# Altium schematic sheet LIDO-HW.200.MCU_Peripherals_1.SchDoc(LIDO-HW.200.MCU_Peripherals_1)
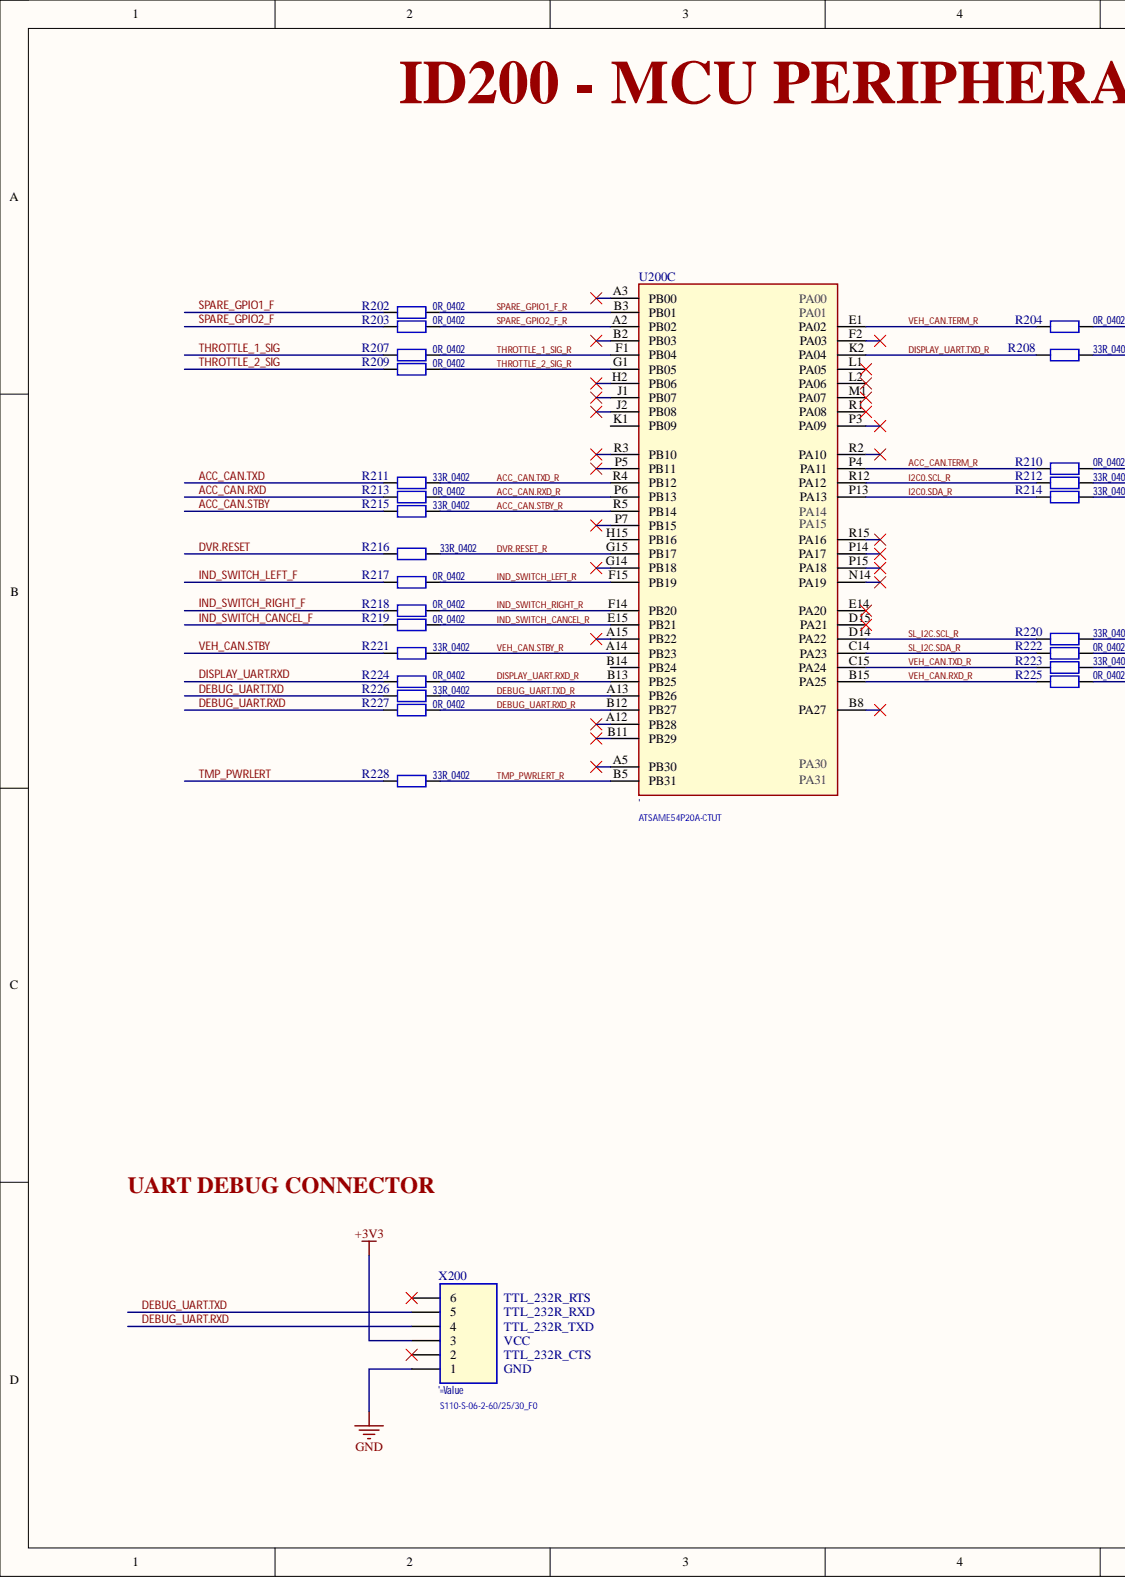
[diagram p0: LIDO-HW.200.MCU_Peripherals_1.SchDoc, left]
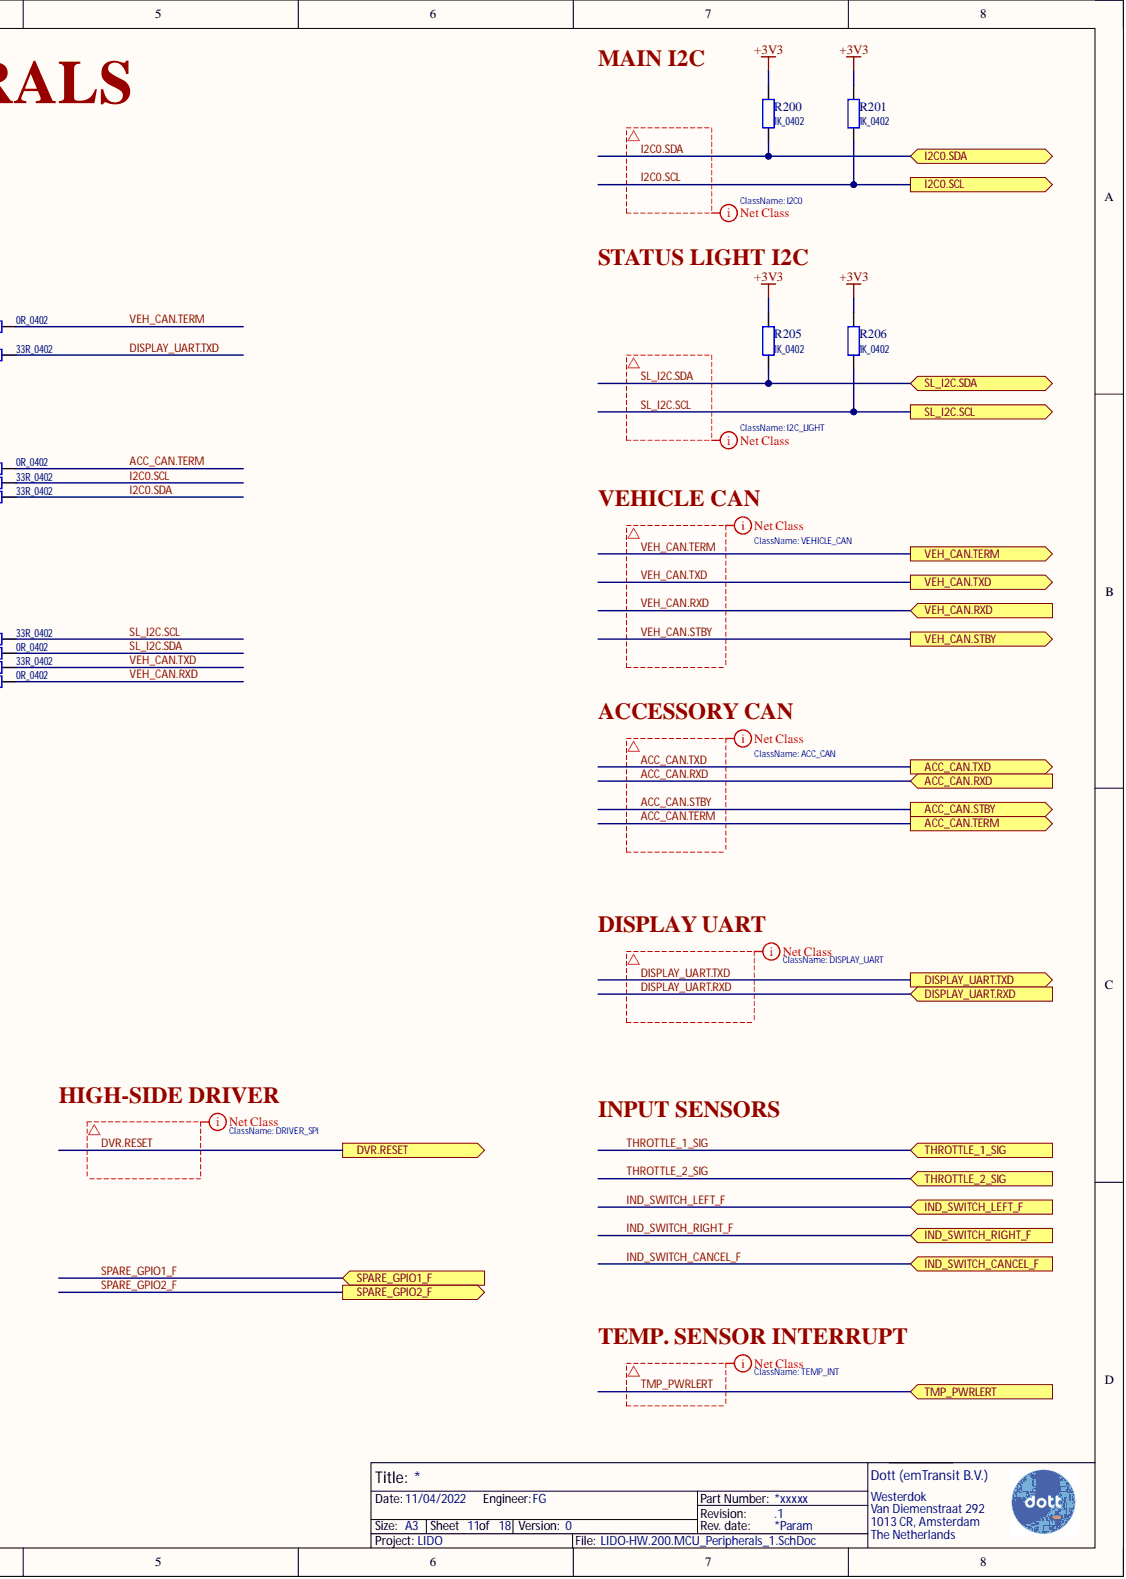
[diagram p1: LIDO-HW.200.MCU_Peripherals_1.SchDoc, right]

## Parts
R200  1K_0402
R201  1K_0402
R202  0R_0402
R203  0R_0402
R204  0R_0402
R205  1K_0402
R206  1K_0402
R207  0R_0402
R208  33R_0402
R209  0R_0402
R210  0R_0402
R211  33R_0402
R212  33R_0402
R213  0R_0402
R214  33R_0402
R215  33R_0402
R216  33R_0402
R217  0R_0402
R218  0R_0402
R219  0R_0402
R220  33R_0402
R221  33R_0402
R222  0R_0402
R223  33R_0402
R224  0R_0402
R225  0R_0402
R226  33R_0402
R227  0R_0402
R228  33R_0402
U200  '  (part C)
X200  '=Value

## Ports
ACC_CAN.RXD, ACC_CAN.STBY, ACC_CAN.TERM, ACC_CAN.TXD, DISPLAY_UART.RXD, DISPLAY_UART.TXD, DVR.RESET, I2C0.SCL, I2C0.SDA, IND_SWITCH_CANCEL_F, IND_SWITCH_LEFT_F, IND_SWITCH_RIGHT_F, SL_I2C.SCL, SL_I2C.SDA, SPARE_GPIO1_F, SPARE_GPIO2_F, THROTTLE_1_SIG, THROTTLE_2_SIG, TMP_PWRLERT, VEH_CAN.RXD, VEH_CAN.STBY, VEH_CAN.TERM, VEH_CAN.TXD

## Nets
+3V3: R200.1 R201.1 R205.1 R206.1 X200.3
GND: X200.1
ACC_CAN.RXD: R213.2 ; port ACC_CAN.RXD
ACC_CAN.RXD_R: R213.1 U200.P6(PB13)
ACC_CAN.STBY: R215.2 ; port ACC_CAN.STBY
ACC_CAN.STBY_R: R215.1 U200.R5(PB14)
ACC_CAN.TERM: R210.1 ; port ACC_CAN.TERM
ACC_CAN.TERM_R: R210.2 U200.P4(PA11)
ACC_CAN.TXD: R211.2 ; port ACC_CAN.TXD
ACC_CAN.TXD_R: R211.1 U200.R4(PB12)
DEBUG_UART.RXD: R227.2 X200.4
DEBUG_UART.RXD_R: R227.1 U200.B12(PB27)
DEBUG_UART.TXD: R226.2 X200.5
DEBUG_UART.TXD_R: R226.1 U200.A13(PB26)
DISPLAY_UART.RXD: R224.2 ; port DISPLAY_UART.RXD
DISPLAY_UART.RXD_R: R224.1 U200.B13(PB25)
DISPLAY_UART.TXD: R208.2 ; port DISPLAY_UART.TXD
DISPLAY_UART.TXD_R: R208.1 U200.K2(PA04)
DVR.RESET: R216.2 ; port DVR.RESET
DVR.RESET_R: R216.1 U200.G15(PB17)
I2C0.SCL: R201.2 R212.1 ; port I2C0.SCL
I2C0.SCL_R: R212.2 U200.R12(PA12)
I2C0.SDA: R200.2 R214.1 ; port I2C0.SDA
I2C0.SDA_R: R214.2 U200.P13(PA13)
IND_SWITCH_CANCEL_F: R219.2 ; port IND_SWITCH_CANCEL_F
IND_SWITCH_CANCEL_R: R219.1 U200.E15(PB21)
IND_SWITCH_LEFT_F: R217.2 ; port IND_SWITCH_LEFT_F
IND_SWITCH_LEFT_R: R217.1 U200.F15(PB19)
IND_SWITCH_RIGHT_F: R218.2 ; port IND_SWITCH_RIGHT_F
IND_SWITCH_RIGHT_R: R218.1 U200.F14(PB20)
SL_I2C.SCL: R206.2 R220.1 ; port SL_I2C.SCL
SL_I2C.SCL_R: R220.2 U200.D14(PA22)
SL_I2C.SDA: R205.2 R222.1 ; port SL_I2C.SDA
SL_I2C.SDA_R: R222.2 U200.C14(PA23)
SPARE_GPIO1_F: R202.2 ; port SPARE_GPIO1_F
SPARE_GPIO1_F_R: R202.1 U200.B3(PB01)
SPARE_GPIO2_F: R203.2 ; port SPARE_GPIO2_F
SPARE_GPIO2_F_R: R203.1 U200.A2(PB02)
THROTTLE_1_SIG: R207.2 ; port THROTTLE_1_SIG
THROTTLE_1_SIG_R: R207.1 U200.F1(PB04)
THROTTLE_2_SIG: R209.2 ; port THROTTLE_2_SIG
THROTTLE_2_SIG_R: R209.1 U200.G1(PB05)
TMP_PWRLERT: R228.2 ; port TMP_PWRLERT
TMP_PWRLERT_R: R228.1 U200.B5(PB31)
VEH_CAN.RXD: R225.1 ; port VEH_CAN.RXD
VEH_CAN.RXD_R: R225.2 U200.B15(PA25)
VEH_CAN.STBY: R221.2 ; port VEH_CAN.STBY
VEH_CAN.STBY_R: R221.1 U200.A14(PB23)
VEH_CAN.TERM: R204.1 ; port VEH_CAN.TERM
VEH_CAN.TERM_R: R204.2 U200.E1(PA02)
VEH_CAN.TXD: R223.1 ; port VEH_CAN.TXD
VEH_CAN.TXD_R: R223.2 U200.C15(PA24)

Not connected: U200 A3(PB00) A5(PB30) A12(PB28) A15(PB22) B2(PB03) B8(PA27) B11(PB29) B14(PB24) D15(PA21) E14(PA20) F2(PA03) G14(PB18) H2(PB06) H15(PB16) J1(PB07) J2(PB08) K1(PB09) L1(PA05) L2(PA06) M1(PA07) N14(PA19) P3(PA09) P5(PB11) P7(PB15) P14(PA17) P15(PA18) R1(PA08) R2(PA10) R3(PB10) R15(PA16); X200 2 6

## Library data of the parts
R200-R228 = Resistor: Resistor Symbol; Component Kind: Standard; Library Name: 02_Resistors.SchLib
U200 = ATMEL_ATSAME54P20A-CT_A: 32-bit ARM® Cortex®-M4F MCUs with 1 Msps 12-bit ADC,  QSPI, USB, Ethernet, and PTC; Component Kind: Standard; Library Name: LIDO_Lib.SchLib
X200 = RECT_6pin_A: Rectengular connector, 6 pins, Version A; Component Kind: Standard; Library Name: 40_Connectors_Rectangular.SchLib
